# Altium schematic sheet SensorBoardSchm.SchDoc
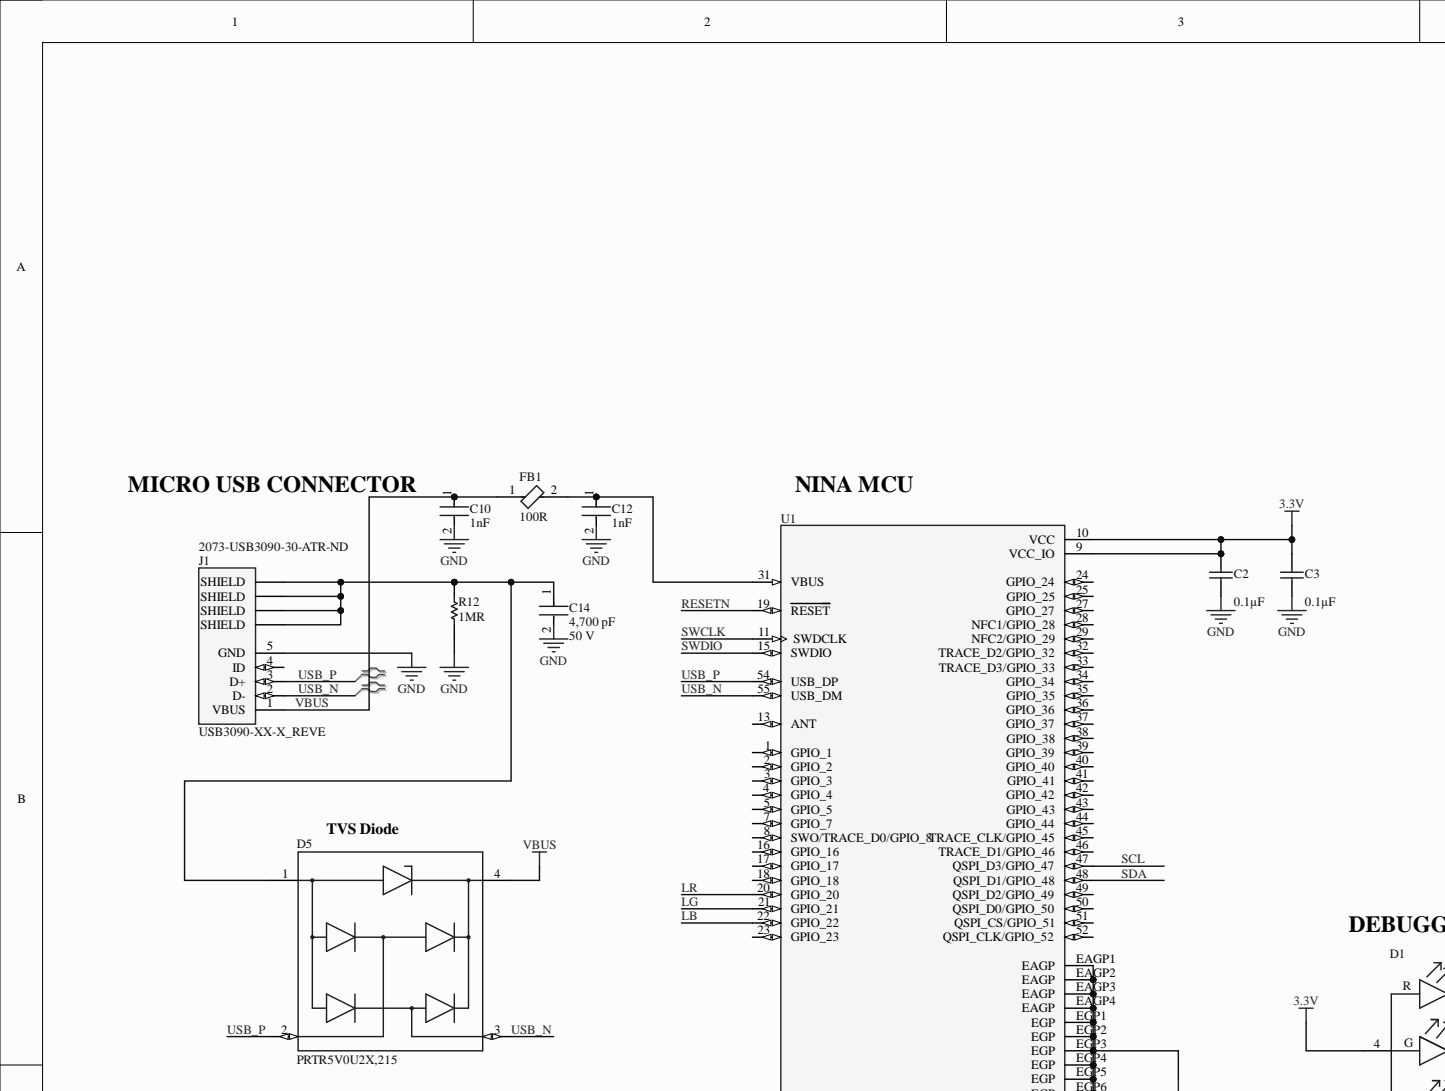
[diagram p0: SensorBoardSchm.SchDoc, top left]
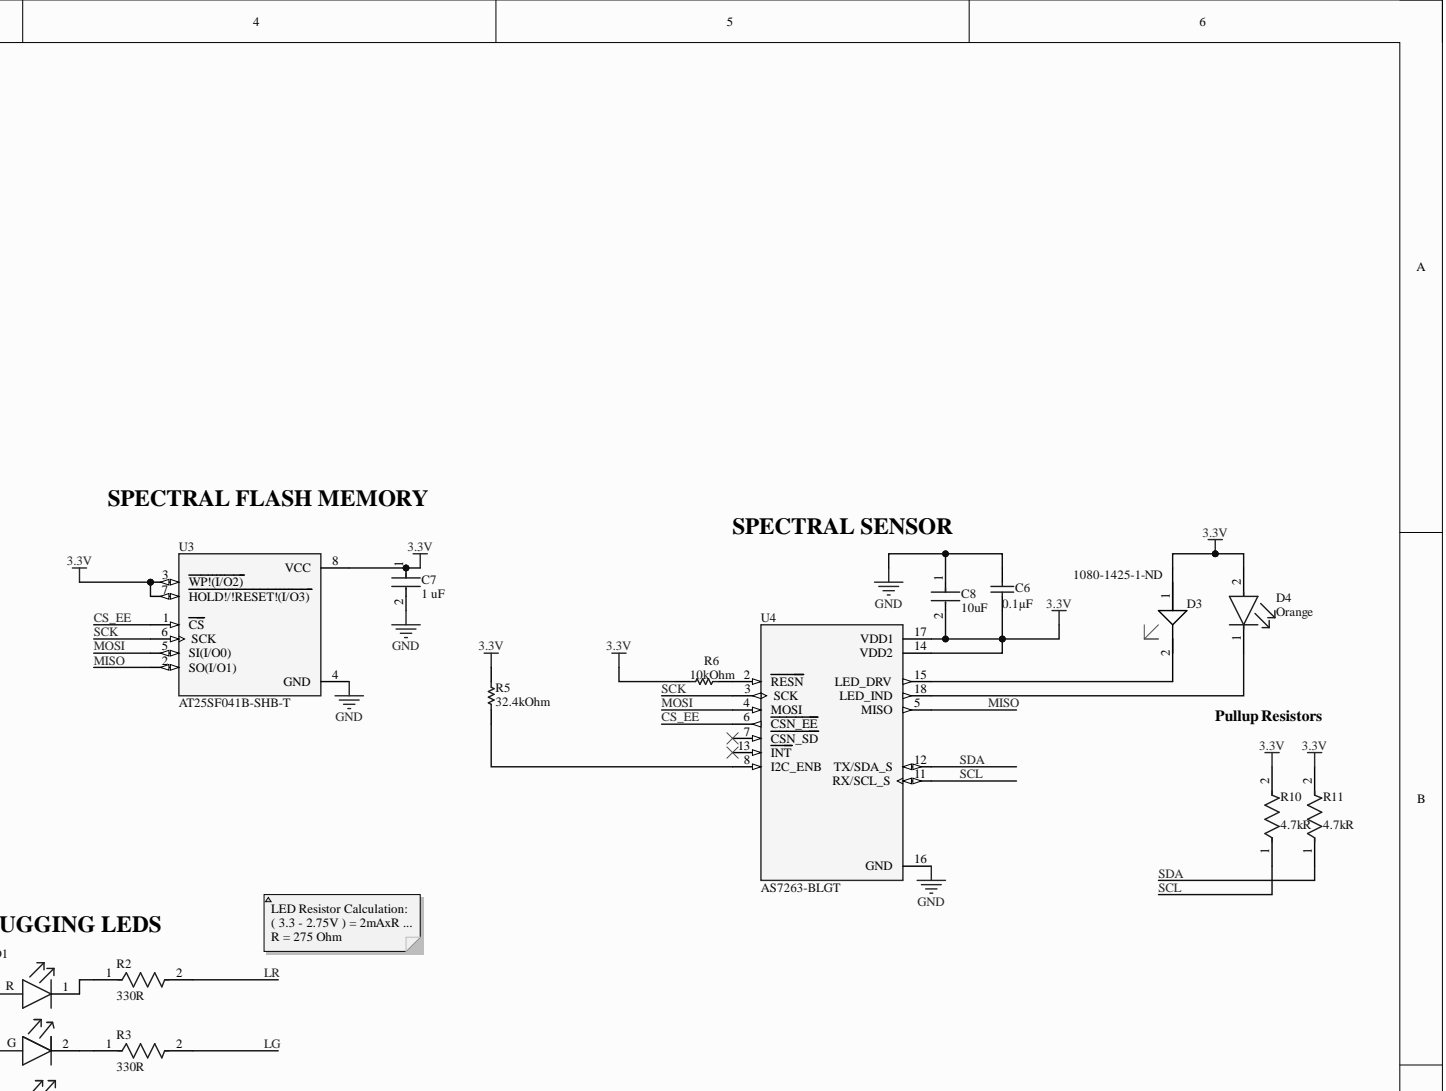
[diagram p1: SensorBoardSchm.SchDoc, top right]
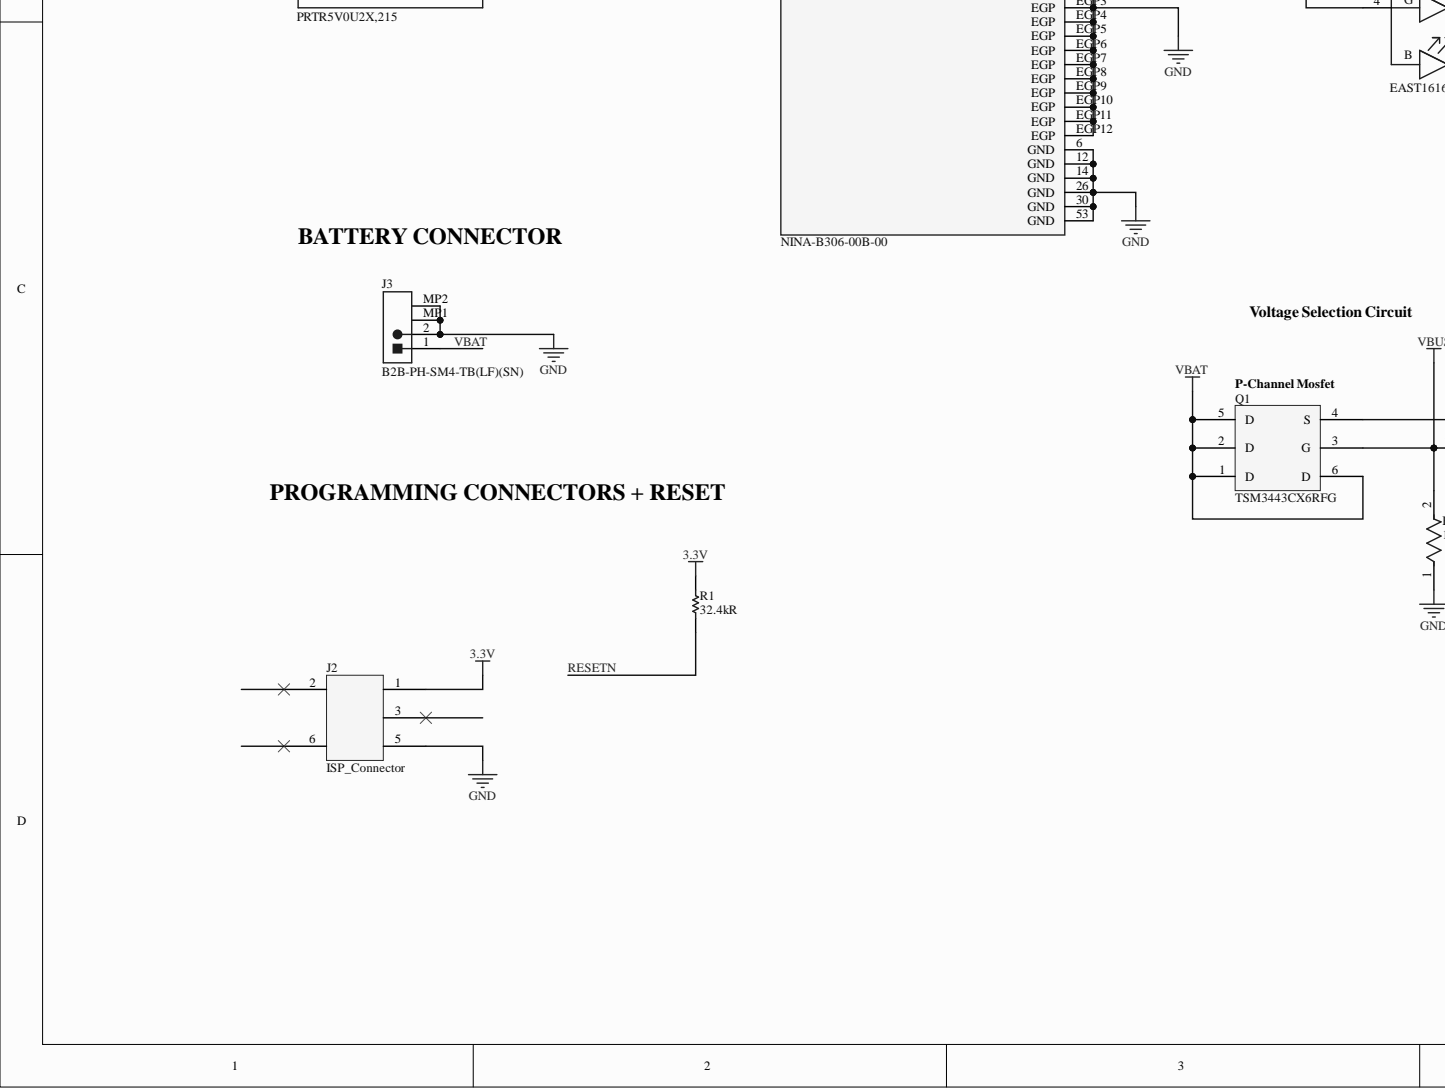
[diagram p2: SensorBoardSchm.SchDoc, bottom left]
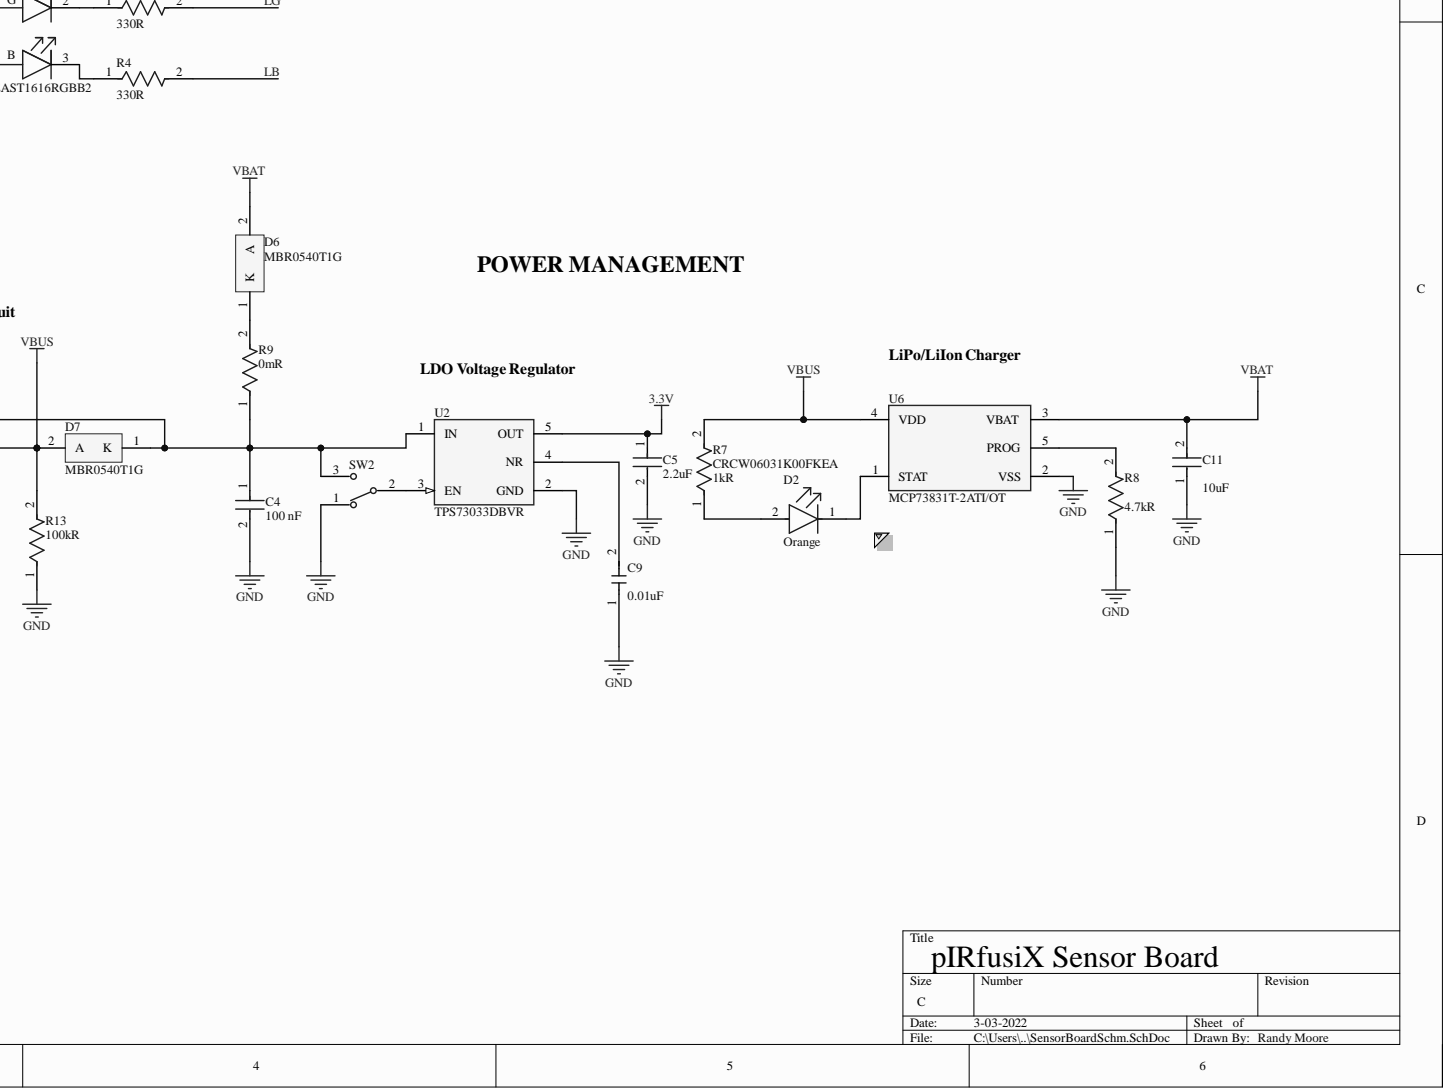
[diagram p3: SensorBoardSchm.SchDoc, bottom right]

## Parts
C2  Capacitance: 0.1µF  [SAMS-C0603-2_M]  (hidden comment: CL10B104KB8NNNC)
C3  Capacitance: 0.1µF  [SAMS-C0603-2_M]  (hidden comment: CL10B104KB8NNNC)
C4  value 100 nF  Capacitance: 100 nF  [FP-CC0603-DA-MFG]  (hidden comment: CC0603KRX7R7BB104)
C5  Capacitance: 2.2uF  [FP-GRM188-0_1-e0_2_0_5-MFG]  (hidden comment: GRM188R71A225KE15D)
C6  Capacitance: 0.1µF  [SAMS-C0603-2_M]  (hidden comment: CL10B104KB8NNNC)
C7  value 1 uF  Capacitance: 1 uF  [FP-CC0603-DA-MFG]  (hidden comment: CC0603KPX7R7BB105)
C8  Capacitance: 10uF  [FP-GRM188-0_1-e0_2_0_55-IPC_A]  (hidden comment: GRM188R60J106ME47D)
C9  Capacitance: 0.01uF  [RESC1608X55N]  (hidden comment: C0603C103K3RACTU)
C10  Capacitance: 1nF  [FP-GRM188-0_1-e0_2_0_5-IPC_C]  (hidden comment: GRM1885C1H102JA01D)
C11  Capacitance: 10uF  [FP-C1608-080-0_1-MFG]  (hidden comment: C1608X5R0J106M080AB)
C12  Capacitance: 1nF  [FP-GRM188-0_1-e0_2_0_5-IPC_C]  (hidden comment: GRM1885C1H102JA01D)
C14  value 4,700 pF  Capacitance: 4,700 pF  Voltage Rating: 50 V  [FP-C0603C-CF-MFG]  (hidden comment: C0603C472J5GACTU)
D1  EAST1616RGBB2  [FP-EAST1616RGBB2-MFG]
D2  Colour: Orange  [FP-6_541-5056_01-4-MFG]  (hidden comment: TLMO1000-GS08)
D3  Supplier Part Number 1: 1080-1425-1-ND  [SIR19-21C/TR8]  (hidden comment: SIR19-21C/TR8)
D4  Colour: Orange  [FP-6_541-5056_01-4-MFG]  (hidden comment: TLMO1000-GS08)
D5  PRTR5V0U2X,215  [FP-SOT143B-MFG]
D6  MBR0540T1G  [ONSC-SOD-123-2-425-04_V]
D7  MBR0540T1G  [ONSC-SOD-123-2-425-04_V]
FB1  Impedance: 100R  [FP-BLM18-0_15-t0_5-MFG]  (hidden comment: BLM18EG101TN1D)
J1  USB3090-XX-X_REVE  Supplier Part Number 1: 2073-USB3090-30-ATR-ND  [GCT_USB3090-XX-X_REVE]
J2  ISP_Connector  [JST Programmer]
J3  B2B-PH-SM4-TB(LF)(SN)  [JST-B2B-PH-SM4-TB-2_V]
Q1  TSM3443CX6RFG  [SOT95P280X145-6N]
R1  Resistance: 32.4kR  [RESC1608X55X30ML15T15]  (hidden comment: ERJ-3EKF3242V)
R2  Resistance: 330R  [FP-CRCW0603-e3-MFG]  (hidden comment: CRCW0603330RFKEA)
R3  Resistance: 330R  [FP-CRCW0603-e3-MFG]  (hidden comment: CRCW0603330RFKEA)
R4  Resistance: 330R  [FP-CRCW0603-e3-MFG]  (hidden comment: CRCW0603330RFKEA)
R5  Resistance: 32.4kOhm  [RESC1608X55X30ML15T15]  (hidden comment: ERJ-3EKF3242V)
R6  Resistance: 10kOhm  [RESC1609X50X30ML10T20]  (hidden comment: CRCW060310K0FKTB)
R7  CRCW06031K00FKEA  Resistance: 1kR  [FP-CRCW0603-e3-MFG]
R8  Resistance: 4.7kR  [FP-CR0603-IPC_B]  (hidden comment: CR0603-FX-4701ELF)
R9  Resistance: 0mR  [FP-CRCW0603-HPe3-MFG]  (hidden comment: CRCW06030000Z0EAHP)
R10  Resistance: 4.7kR  [FP-CR0603-IPC_B]  (hidden comment: CR0603-FX-4701ELF)
R11  Resistance: 4.7kR  [FP-CR0603-IPC_B]  (hidden comment: CR0603-FX-4701ELF)
R12  Resistance: 1MR  [RESC1608X55X25LL10T15]  (hidden comment: RC0603FR-071ML)
R13  Resistance: 100kR  [FP-RC0603-0_55-MFG]  (hidden comment: RC0603FR-07100KL)
SW2  JS102011JAQN  [FP-JS102011JAQN-MFG]  (value not drawn)
U1  NINA-B306-00B-00  [XCVR_NINA-B306-00B-00]
U2  TPS73033DBVR  [FP-DBV0005A-MFG]
U3  AT25SF041B-SHB-T  [SOIC127P790X216-8N]
U4  AS7263-BLGT  [AS7263Footprint]
U6  MCP73831T-2ATI/OT  [FP-C04-091-OT-IPC_C]

## Nets
3.3V: C2.2 C3.2 C5.1 C6.1 C7.1 C8.2 D1.4 D3.1 D4.2 J2.1 R1.2 R5.2 R6.1 R10.2 R11.2 U1.9(VCC_IO) U1.10(VCC) U2.5(OUT) U3.3(WP!(I/O2)) U3.7(HOLD!/!RESET!(I/O3)) U3.8(VCC) U4.14(VDD2) U4.17(VDD1)
GND: C2.1 C3.1 C4.2 C5.2 C6.2 C7.2 C8.1 C9.1 C10.2 C11.1 C12.2 C14.2 J1.5(GND) J2.5 J3.2 J3.MP1 J3.MP2 R8.1 R12.1 R13.1 SW2.1 U1.6(GND) U1.12(GND) U1.14(GND) U1.26(GND) U1.30(GND) U1.53(GND) U1.EAGP1(EAGP) U1.EAGP2(EAGP) U1.EAGP3(EAGP) U1.EAGP4(EAGP) U1.EGP1(EGP) U1.EGP2(EGP) U1.EGP3(EGP) U1.EGP4(EGP) U1.EGP5(EGP) U1.EGP6(EGP) U1.EGP7(EGP) U1.EGP8(EGP) U1.EGP9(EGP) U1.EGP10(EGP) U1.EGP11(EGP) U1.EGP12(EGP) U2.2(GND) U3.4(GND) U4.16(GND) U6.2(VSS)
CS_EE: U3.1(CS) U4.6(CSN_EE)
LB: R4.2 U1.22(GPIO_22)
LG: R3.2 U1.21(GPIO_21)
LR: R2.2 U1.20(GPIO_20)
MISO: U3.2(SO(I/O1)) U4.5(MISO)
MOSI: U3.5(SI(I/O0)) U4.4(MOSI)
RESETN: R1.1 U1.19(RESET)
SCK: U3.6(SCK) U4.3(SCK)
SCL: R10.1 U1.47(QSPI_D3/GPIO_47) U4.11(RX/SCL_S)
SDA: R11.1 U1.48(QSPI_D1/GPIO_48) U4.12(TX/SDA_S)
SWCLK: U1.11(SWDCLK)
SWDIO: U1.15(SWDIO)
USB_N: D5.3 J1.2(D-) U1.55(USB_DM)
USB_P: D5.2 J1.3(D+) U1.54(USB_DP)
VBAT: C11.2 D6.2 J3.1 Q1.1(D) Q1.2(D) Q1.5(D) Q1.6(D) U6.3(VBAT)
VBUS: C10.1 D5.4 D7.2 FB1.1 J1.1(VBUS) Q1.3(G) R7.2 R13.2 U6.4(VDD)
NetC4_1: C4.1 D7.1 Q1.4(S) R9.1 SW2.3 U2.1(IN)
NetC9_2: C9.2 U2.4(NR)
NetC12_1: C12.1 FB1.2 U1.31(VBUS)
NetC14_1: C14.1 D5.1 J1.6(SHIELD) J1.7(SHIELD) J1.8(SHIELD) J1.9(SHIELD) R12.2
NetD1_1: D1.1 R2.1
NetD1_2: D1.2 R3.1
NetD1_3: D1.3 R4.1
NetD2_1: D2.1 U6.1(STAT)
NetD2_2: D2.2 R7.1
NetD3_2: D3.2 U4.15(LED_DRV)
NetD4_1: D4.1 U4.18(LED_IND)
NetD6_1: D6.1 R9.2
NetR5_1: R5.1 U4.8(I2C_ENB)
NetR6_2: R6.2 U4.2(RESN)
NetR8_2: R8.2 U6.5(PROG)
NetSW2_2: SW2.2 U2.3(EN)

Not connected: J1 4(ID); J2 2 3 6; U1 1(GPIO_1) 2(GPIO_2) 3(GPIO_3) 4(GPIO_4) 5(GPIO_5) 7(GPIO_7) 8(SWO/TRACE_D0/GPIO_8) 13(ANT) 16(GPIO_16) 17(GPIO_17) 18(GPIO_18) 23(GPIO_23) 24(GPIO_24) 25(GPIO_25) 27(GPIO_27) 28(NFC1/GPIO_28) 29(NFC2/GPIO_29) 32(TRACE_D2/GPIO_32) 33(TRACE_D3/GPIO_33) 34(GPIO_34) 35(GPIO_35) 36(GPIO_36) 37(GPIO_37) 38(GPIO_38) 39(GPIO_39) 40(GPIO_40) 41(GPIO_41) 42(GPIO_42) 43(GPIO_43) 44(GPIO_44) 45(TRACE_CLK/GPIO_45) 46(TRACE_D1/GPIO_46) 49(QSPI_D2/GPIO_49) 50(QSPI_D0/GPIO_50) 51(QSPI_CS/GPIO_51) 52(QSPI_CLK/GPIO_52); U4 7(CSN_SD) 13(INT)

## Library data of the parts
C2 C3 C6 = CMP-2000-05332-1: Multi-layer Ceramic Capacitor, -55 to 125 degC, 2-Pin SMD (0603), RoHS, Tape and Reel; Manufacturer: Samsung Electro-Mechanics; Dielectric: X7R; Rated Voltage: 50V; Tolerance (%): ±10%; Case Size/Dimension: 0603; Component Kind: Standard; Datasheet URL: https://datasheet.ciiva.com/29198/456651-da-01-en-vs-kondensator-0605-29198839.pdf; Datasheet Version: Nov-10; Library Name: Altium Content Vault; Manufacturer URL: http://www.samsungsem.com/global/index.jsp
C4 = CMP-2000-05002-2: CAP CER 0.1UF 16V X7R 0603; Manufacturer: YAGEO; Material: Ceramic; Temperature Coefficient: 15%; Tolerance: 0.1; Automotive: No; Category: Cap; Component Kind: Standard; ComponentLink2Description: Datasheet; ComponentLink2URL: http://www.yageo.com/documents/recent/UPY-GPHC_X7R_6.3V-to-50V_18.pdf; Device Class L1: Passive Components; Device Class L2: Capacitors; Device Class L3: Ceramic Capacitors; Digikey Description: CAP CER 0.1UF 16V X7R 0603; Footprint Url: http://www.yageo.com/exep/pages/download/literatures/UPY-C_GEN_24.pdf; Height: 0.9mm; Lead Free: Yes; Library Name: Altium Content Vault; Manufacturer Part Number: CC0603KRX7R7BB104; Mouser Description: Multilayer Ceramic Capacitor, CC Series, 0.1 - F, - 10%, X7R, 16 V, 0603 [1608 Metric]; Octopart: https://octopart.com/cc0603krx7r7bb104-yageo-192941; Package: CC0603-DA; RoHS: TRUE; Temperature: -55°C to +125°C; Temperature Characteristic: X7R; Temperature Range High: +125°C; Temperature Range Low: -55°C; Voltage: 16 V; Voltage Rating: 16 V
C5 = CMP-2006-02836-2: Chip Multilayer Ceramic Capacitors for General Purpose, 0603, 2.2uF, X7R, 15%, 10%, 10V; Case Code (Imperial): 0603; Case Code (Metric): 1608; Case/Package: 0603; Component Kind: Standard; Depth: 0.8mm; Dielectric: X7R; Height: 0.8mm; Lead Free: Lead Free; Length: 1.6mm; Library Name: Altium Content Vault; Material: Ceramic; Max Operating Temperature: 125°C; Min Operating Temperature: -55°C; Mount: Surface Mount; Number of Pins: 2; Package Quantity: 1; Packaging: Cut Tape; REACH SVHC: No SVHC; Termination: SMD/SMT; Thickness: 0.035inch; Tolerance: 10%; Voltage: 10V; Voltage Rating: 10V; Voltage Rating (DC): 10V; Weight: 7.1E-05oz; Width: 0.031inch
C7 = CMP-03422-001047-1: CC0603 1 uF X7R 10.00% 16 V; Manufacturer: YAGEO; Material: Ceramic; Temperature Coefficient: 15%; Tolerance: 0.1; Voltage: 16 V; Automotive: No; Category: Cap; Component Kind: Standard; ComponentLink2Description: Datasheet; ComponentLink2URL: http://www.yageo.com/documents/recent/UPY-GPHC_X7R_6.3V-to-50V_18.pdf; Device Class L1: Passive Components; Device Class L2: Capacitors; Device Class L3: Ceramic Capacitors; Footprint Url: http://www.yageo.com/exep/pages/download/literatures/UPY-C_GEN_24.pdf; Height: 0.9mm; Lead Free: Yes; Library Name: Altium Content Vault; Manufacturer Part Number: CC0603KPX7R7BB105; Mouser Description: Cap Ceramic 1uF 16V X7R 10% SMD 0603 125°C Paper T/R; Octopart: https://octopart.com/cc0603kpx7r7bb105-yageo-25629533; Package: CC0603-DA; RoHS: TRUE; Temperature: -55°C to +125°C; Temperature Characteristic: X7R; Temperature Range High: +125°C; Temperature Range Low: -55°C; Voltage Rating: 16 V
C8 = CMP-2006-02792-2: Chip Multilayer Ceramic Capacitors for General Purpose, 0603, 10uF, X5R, 15%, 20%, 6.3V; Case Code (Imperial): 0603; Case Code (Metric): 1608; Case/Package: 0603; Component Kind: Standard; Depth: 0.8mm; Dielectric: X5R; Height: 0.8mm; Lead Free: Lead Free; Length: 1.6mm; Library Name: Altium Content Vault; Material: Ceramic; Max Operating Temperature: 85°C; Min Operating Temperature: -55°C; Mount: Surface Mount; Package Quantity: 4000; Packaging: Tape and Reel; Radiation Hardening: No; REACH SVHC: No SVHC; Termination: SMD/SMT; Thickness: 0.035inch; Tolerance: 20%; Voltage: 6.3V; Voltage Rating: 6.3V; Voltage Rating (DC): 6.3V; Width: 0.031inch
C10 C12 = CMP-1662-00022-2: Chip Multilayer Ceramic Capacitors for General Purpose, 0603, 1000pF, C0G, 30ppm/°C, 5%, 50V; Insulation Resistance: 10GR; Case Code (Imperial): 0603; Case Code (Metric): 1608; Case/Package: 0603; Component Kind: Standard; Depth: 0.8mm; Dielectric: C0G; Dielectric Material: Ceramic; Height: 0.8mm; Lead Free: Lead Free; Length: 1.6mm; Library Name: Altium Content Vault; Material: Ceramic; Max Operating Temperature: 125°C; Min Operating Temperature: -55°C; Mount: Surface Mount; Number of Pins: 2; Package Quantity: 1; Packaging: Tape and Reel; Radiation Hardening: No; REACH SVHC: No SVHC; Temperature Coefficient: 30ppm/°C; Termination: SMD/SMT; Thickness: 0.035inch; Tolerance: 5%; Voltage: 50V; Voltage Rating: 50V; Voltage Rating (DC): 50V; Width: 0.8mm
C11 = CMP-2000-04978-2: Multilayer Ceramic Capacitors 10μF ±20% 6.3V X5R SMD 0603; Case Code (Imperial): 0603; Case Code (Metric): 1608; Case/Package: 0603; Component Kind: Standard; Construction: Flat; Depth: 0.8mm; Dielectric: X5R; Dielectric Material: Ceramic; Height: 0.8mm; Lead Free: Lead Free; Length: 1.6mm; Library Name: Altium Content Vault; Max Operating Temperature: 85°C; Min Operating Temperature: -55°C; Mount: Surface Mount; Package Quantity: 1; Packaging: Tape and Reel; Radiation Hardening: No; REACH SVHC: No SVHC; Resistor Type: Ceramic; RoHS Compliant: Yes; Series: C; Termination: SMD/SMT; Thickness: 0.035inch; Tolerance: 20%; Voltage: 6.3V; Voltage Rating: 6.3V; Voltage Rating (DC): 6.3V; Weight: 7.1E-05oz; Width: 0.031inch
C14 = CMP-2006-03333-2: CAP CER 4700PF 50V 5% NP0 0603; Manufacturer: KEMET; Automotive Grade: Grade 1; Material: Ceramic; Temperature Characteristic: C0G; Temperature Coefficient: 30ppm/°C; Tolerance: 0.05; Automotive: Yes; Category: Cap; Component Kind: Standard; ComponentLink2Description: Datasheet; ComponentLink2URL: https://api.kemet.com/component-edge/download/datasheet/C0603C100J5GACAUTO.pdf; Device Class L1: Passive Components; Device Class L2: Capacitors; Device Class L3: Ceramic Capacitors; Digikey Description: CAP CER 4700PF 50V 5% NP0 0603; Height: 0.87mm; Lead Free: Yes; Library Name: Altium Content Vault; Manufacturer Part Number: C0603C472J5GACTU; Mouser Description: Multilayer Ceramic Capacitors MLCC - SMD/SMT 50volts 4700pF 5% C0G; Octopart: https://octopart.com/c0603c472j5gactu-kemet-9145067; Package: C0603C-CF; RoHS: TRUE; Temperature: -55°C to +125°C; Temperature Range High: +125°C; Temperature Range Low: -55°C
D1 = CMP-51564-000002-1: LED RGB DIFFUSED 4SMD; Manufacturer: Everlight; Colour: Blue, Green, Red; Forward Current: 5mA; Forward Voltage: 3/3/2.1V; Led Orientation: Top View; Luminous Intensity: 36/140/45mcd; Peak Emmision Wavelength: 468/518/632nm; Power Dissipation: 95/95/60mW; Reverse Voltage: 5V; Viewing Angle: 120°; Wavelength: 475/535/628nm; Automotive: No; Category: Diode; Component Kind: Standard; ComponentLink2Description: Datasheet; ComponentLink2URL: https://everlightamericas.com/index.php?controller=attachment&id_attachment=2426; Device Class L1: Optoelectronics; Device Class L2: LEDs; Device Class L3: unset; Digikey Description: LED RGB DIFFUSED 4SMD; Height: 0.45mm; Lead Free: Yes; Library Name: Altium Content Vault; Manufacturer Part Number: EAST1616RGBB2; Octopart: https://octopart.com/east1616rgbb2-everlight-80328051; Package: 0; RoHS: TRUE; Temperature: -40°C to +85°C; Temperature Range High: +85°C; Temperature Range Low: -40°C
D2 D4 = CMP-02520-000010-1: LED ORANGE 0603 SMD; Manufacturer: Vishay; Automotive Grade: Grade 2; Forward Current: 2mA; Forward Voltage: 1.8V; Led Orientation: Top View; Luminous Intensity: 7.5mcd; Max Junction Temp: +120°C; Peak Emmision Wavelength: 610nm; Power Dissipation: 40mW; Reverse Voltage: 6V; Viewing Angle: 160°; Wavelength: 605nm; Ambient Temperature Range High: +100°C; Ambient Temperature Range Low: -40°C; Automotive: Yes; Category: Diode; Component Kind: Standard; ComponentLink2Description: Datasheet; ComponentLink2URL: http://www.vishay.com/docs/83172/tlmo1000.pdf; Device Class L1: Optoelectronics; Device Class L2: LEDs; Device Class L3: unset; Digikey Description: LED ORANGE 0603 SMD; Height: 0.65mm; Lead Free: Yes; Library Name: Altium Content Vault; Manufacturer Part Number: TLMO1000-GS08; Octopart: https://octopart.com/tlmo1000-gs08-vishay-41955669; Package: 6.541-5056.01-4; RoHS: TRUE; Temperature: -40°C to +100°C; Temperature Range High: +100°C; Temperature Range Low: -40°C
D5 = CMP-14436-000007-1: TVS DIODE 5.5V SOT143B; Component Kind: Standard; Library Name: Altium Content Vault
D6 D7 = CMP-1055-00401-1: Schottky Power Rectifier, 2-Pin SOD-123, Pb-Free, Tape and Reel; Manufacturer: On Semiconductor; Configuration: Single; IFSM Max (A): 5.5; Component Kind: Standard; ComponentLink1Description: Manufacturer URL; ComponentLink1URL: http://www.onsemi.com/; ComponentLink2Description: Datasheet; ComponentLink2URL: http://www.onsemi.cn/pub_link/Collateral/MBR0540T1-D.PDF; ComponentLink3Description: Package Specification; ComponentLink3URL: http://www.onsemi.com/pub_link/Collateral/425-04.PDF; DatasheetVersion: Rev. 7; Library Name: Altium Content Vault; Mounting Technology: Surface Mount; PackageDescription: 2-Pin SOD, Body 1.6 x 2.69 mm; PackageReference: SOD-123-2-425-04; PackageVersion: Rev. G, 10/2009; Packing: Tape and Reel; PartNumber: MBR0540T1G; RoHS: TRUE
FB1 = CMP-0686-00530-3: Chip Ferrite Bead, 0603, 100Ω @ 100MHz, 25%, 0.045Ω, 2A; DC Resistance (DCR): 45mR; Number of Circuits: 1; Case Code (Imperial): 0603; Case Code (Metric): 1608; Case/Package: 0603; Component Kind: Standard; Contact Plating: Tin; Core Material: Ferrite; Current Rating: 2A; Depth: 0.8mm; Height: 0.8mm; Lead Free: Lead Free; Length: 1.6mm; Library Name: Altium Content Vault; Material: Ferrite; Max Current Rating: 2A; Max DC Current: 2A; Max Operating Temperature: 125°C; Min Operating Temperature: -55°C; Number of Lines: 1; Number of Pins: 2; Package Quantity: 1; Packaging: Tape and Reel; REACH SVHC: No SVHC; Termination: SMD/SMT; Test Frequency: 100MHz; Tolerance: 25%; Width: 0.8mm
J1 = USB3090-XX-X_REVE: USB - micro AB USB 2.0 Receptacle Connector 5 Position Surface Mount, Right Angle; Through Hole; MF: Global Connector Technology; MP: USB3090-30-A; Availability: Unavailable; Component Kind: Standard; Library Name: USB3090-XX-X_REVE.IntLib; Package: None; Price: None; SnapEDA_Link: https://www.snapeda.com/parts/USB3090-30-A/Global%20Connector%20Technology/view-part/281875/?ref=snap; Supplier 1: Digi-Key
J3 = CMP-2000-05163-1: Male Header, Pitch 2 mm, 1 x 2 Position, Height 6.6 mm, RoHS, Tape and Reel; Manufacturer: JST; No of Positions: 2; Pitch: 2mm; Style/Orientation: StraightHeader/Plug; Component Kind: Standard; Datasheet URL: https://ciiva.com/datasheet-click-26894756?src=ciiva&sig=90099765; Datasheet Version: Dec-12; Library Name: Altium Content Vault; Manufacturer URL: http://www.jst.com/home2.html; Termination: Crimp; Type: ShroudedHeader
R1 R5 = CMP-2000-00433-1: Manufacturer: Panasonic; Component Kind: Standard; Library Name: Altium Content Vault; Part Number: ERJ-3EKF3242V
R2-R4 = CMP-1012-00454-3: RES Thick Film, 330Ω, 1%, 0.1W, 100ppm/°C, 0603; Max Power Dissipation: 100mW; Voltage Rating: 75V; Case Code (Imperial): 0603; Case Code (Metric): 1608; Case/Package: 0603; Component Kind: Standard; Composition: Thick Film; Contact Plating: Tin; Depth: 0.85mm; Features: Automotive AEC-Q200; Height: 0.45mm; Lead Free: Lead Free; Length: 1.55mm; Library Name: Altium Content Vault; Max Operating Temperature: 155°C; Military Standard: Not; Min Operating Temperature: -55°C; Mount: Surface Mount; Number of Terminations: 2; Package Quantity: 10; Packaging: Tape and Reel; Power Rating: 100mW; Radiation Hardening: No; Temperature Coefficient: 100ppm/°C; Termination: SMD/SMT; Tolerance: 1%; Voltage Rating (DC): 75V; Weight: 7.1E-05oz; Width: 0.033inch
R6 = CMP-2000-03837-1: Manufacturer: Vishay Dale; Component Kind: Standard; Library Name: Altium Content Vault; Part Number: CRCW060310K0FKTB
R7 = CMP-1666-00003-5: RES Thick Film, 1kΩ, 1%, 0.1W, 100ppm/°C, 0603; Max Power Dissipation: 100mW; Voltage Rating: 75V; Case Code (Imperial): 0603; Case Code (Metric): 1608; Case/Package: 0603; Component Kind: Standard; Composition: Thick Film; Contact Plating: Tin; Depth: 0.85mm; Features: Automotive AEC-Q200; Height: 0.45mm; Lead Free: Lead Free; Length: 1.55mm; Library Name: Altium Content Vault; Max Operating Temperature: 155°C; Military Standard: Not; Min Operating Temperature: -55°C; Mount: Surface Mount; Number of Pins: 2; Number of Terminations: 2; Package Quantity: 10; Packaging: Tape and Reel; Power Rating: 100mW; Radiation Hardening: No; Temperature Coefficient: 100ppm/°C; Termination: SMD/SMT; Tolerance: 1%; Voltage Rating (DC): 75V; Weight: 7.1E-05oz; Width: 0.033inch
R8 R10 R11 = CMP-07231-003020-1: Thick Film Chip Resistors 0603 4.7kΩ 0.1W 1% 100ppm/°C; Max Power Dissipation: 100mW; Voltage Rating: 50V; Case Code (Imperial): 0603; Case Code (Metric): 1608; Case/Package: 0603; Component Kind: Standard; Composition: Thick Film; Contact Plating: Tin; Depth: 0.8mm; Features: Moisture Resistant; Height: 0.45mm; Length: 1.6mm; Library Name: Altium Content Vault; Max Operating Temperature: 155°C; Min Operating Temperature: -55°C; Mount: Surface Mount; Number of Pins: 2; Number of Terminations: 2; Package Quantity: 1; Packaging: Tape and Reel; Power Rating: 100mW; Radiation Hardening: No; REACH SVHC: No SVHC; Temperature Coefficient: 100ppm/°C; Termination: SMD/SMT; Thickness: 457um; Tolerance: 1%; Voltage Rating (DC): 50V; Width: 0.8mm
R9 = CMP-2000-03817-2: RES Thick Film, 0Ω, , 0.33W, , 0603; Max Power Dissipation: 250mW; Temperature Coefficient: 0ppm/°C; Voltage Rating: 75V; Case Code (Imperial): 0603; Case Code (Metric): 1608; Case/Package: 0603; Component Kind: Standard; Composition: Thick Film; Contact Plating: Tin; Depth: 0.85mm; Features: Automotive AEC-Q200; Height: 0.45mm; Lead Free: Lead Free; Length: 1.6mm; Library Name: Altium Content Vault; Max Operating Temperature: 155°C; Military Standard: Not; Min Operating Temperature: -55°C; Mount: Surface Mount; Number of Pins: 2; Number of Terminations: 2; Package Quantity: 5000; Packaging: Tape and Reel; Power Rating: 250mW; Radiation Hardening: No; Termination: SMD/SMT; Voltage Rating (DC): 75V; Weight: 7.1E-05oz; Width: 0.033inch
R12 = CMP-1659-00056-1: Chip Resistor, 1 MOhm, +/- 1%, 100 mW, -55 to 155 degC, 0603 (1608 Metric), RoHS, Tape and Reel; Capacitance: 1 mF; Case\Package: Radial; Tolerance: 20%; Voltage Rating: 25 V; Component Kind: Standard; ComponentLink1Description: Manufacturer URL; ComponentLink1URL: http://www.yageo.com/; ComponentLink2Description: Datasheet; ComponentLink2URL: http://www.yageo.com/documents/recent/PYu-RC_Group_51_RoHS_L_04.pdf; DatasheetVersion: Rev. 4, 02/2016; Diameter: 10 mm; Height: 20 mm; Lead Pitch: 5 mm; Length: 20 mm; Library Name: Altium Content Vault; Max Operating Temperature: 85 degC; Min Operating Temperature: -40 degC; Mount: Through Hole; Package Quantity: 200; PackageDescription: 2-Pin Surface Mount Device, Body 1.6 x 0.8 mm; PackageReference: 0603; Packaging: Bulk; Radiation Hardening: No; REACH SVHC: No SVHC; RoHSCompliant: true; Voltage Rating (DC): 25 V; Width: 0 inch
R13 = CMP-2100-03662-2: RES SMD 100K OHM 1% 1/10W 0603; Max Power Dissipation: 100mW; Temperature Coefficient: 100ppm/°C; Voltage Rating: 50V; Working Voltage: 75V; Case Code (Imperial): 0603; Case Code (Metric): 1608; Case/Package: 0603; Component Kind: Standard; Construction: Rectangular; Depth: 0.8mm; Features: Moisture Resistant; Height: 0.45mm; Lead Free: Lead Free; Length: 1.6mm; Library Name: Altium Content Vault; Max Operating Temperature: 155°C; Military Standard: Not; Min Operating Temperature: -55°C; Number of Pins: 2; Number of Terminations: 2; Packaging: Tape and Reel; Power Rating: 100mW; REACH SVHC: No SVHC; Resistance Tolerance: 1%; Resistor Type: Thick Film; RoHS Compliant: Yes; Series: RC; Technology: THICK FILM; Termination: SMD/SMT; Tolerance: 1%; Voltage Rating (DC): 50V; Width: 0.8mm
SW2 = CMP-10038-000001-1: SWITCH SLIDE SPDT 300MA 6V; Manufacturer: C&K; Contact Resistance: 70mΩ; Electromechanical Life: 5000Cycles; Automotive: No; Category: Switch; Component Kind: Standard; ComponentLink2Description: Datasheet; ComponentLink2URL: https://www.ckswitches.com/media/1422/js.pdf; Contact Current Rating: 300mA; Device Class L1: Electromechanical; Device Class L2: Switches; Device Class L3: Slide Switches; Digikey Description: SWITCH SLIDE SPDT 300MA 6V; Height: 3.5mm; Lead Free: Yes; Library Name: Altium Content Vault; Manufacturer Part Number: JS102011JAQN; Mount: Surface Mount; Mouser Description: JS Series Sub-Miniature Slide Switch SPDT Right-Angle SMT; Octopart: https://octopart.com/js102011jaqn-c%26k+components-74831566; Package: JS102011JAQN; RoHS: TRUE; Temperature: -40°C to +85°C; Temperature Range High: +85°C; Temperature Range Low: -40°C; Throw Configuration: SPDT; Voltage Rating Dc: 6V
U1 = NINA-B306-00B-00: With internal PCB antenna, 10.0 x 15.0 x 2.2 mm, use with open CPU; MF: u-blox; Availability: Unavailable; Component Kind: Standard; Library Name: SensorBoardSchLib.SchLib; MP: NINA-B306-00B-00; Package: SMD-55 u-blox; Price: None; SnapEDA_Link: https://www.snapeda.com/parts/NINA-B306-00B-00/u-blox/view-part/4176731/?ref=snap
U2 = CMP-04917-000026-1: IC REG LINEAR 3.3V 200MA SOT23-5; Manufacturer: Texas Instruments; Accuracy Percentage: 2%; Dropout Voltage: 120mV; Max Junction Temp: +125°C; Max Supply Voltage: 5.5V; Min Supply Voltage: 2.7V; Nominal Supply Current: 170uA; Number Of Outputs: 1; Output Type: Fixed; Standoff Height: 0mm; Automotive: No; Category: IC; Component Kind: Standard; ComponentLink2Description: Datasheet; ComponentLink2URL: http://www.ti.com/lit/ds/symlink/tps730.pdf; Device Class L1: Integrated Circuits (ICs); Device Class L2: Power Management ICs; Device Class L3: Voltage Regulators - Linear; Digikey Description: IC REG LINEAR 3.3V 200MA SOT23-5; Height: 1.45mm; Lead Free: Yes; Library Name: Altium Content Vault; Manufacturer Part Number: TPS73033DBVR; Mouser Description: IC REG LINEAR 3.3V 200MA SOT23-5; Octopart: https://octopart.com/tps73033dbvr-texas+instruments-525554; Output Current: 200mA; Output Voltage: 3.3V; Package: DBV0005A; RoHS: TRUE; Temperature: -40°C to +125°C; Temperature Range High: +125°C; Temperature Range Low: -40°C
U3 = AT25SF041B-SHB-T: 4 Mbit, 3.0V (2.7V to 3.6V), -40C to 85C, SOIC-W 208mil (Tape & Reel), Single, Dual, Quad SPI NOR flash; MF: Dialog Semiconductor; Availability: Warning; Check_prices: https://www.snapeda.com/parts/AT25SF041B-SHB-T/Dialog%20Semiconductor/view-part/5488498/?ref=eda; Component Kind: Standard; Library Name: SensorBoardSchLib.SchLib; MP: AT25SF041B-SHB-T; Package: SOIC-8 Dialog Semiconductor; Price: None; Purchase-URL: https://pricing.snapeda.com/search/part/AT25SF041B-SHB-T/?ref=eda; SnapEDA_Link: https://www.snapeda.com/parts/AT25SF041B-SHB-T/Dialog%20Semiconductor/view-part/5488498/?ref=snap; Supplier 1: Digi-Key; Supplier Part Number 1: 1265-AT25SF041B-SHB-TCT-ND
U4 = AS7263-BLGT: Optical Sensor Ambient 610nm, 680nm, 730nm, 760nm, 810nm, 860nm IÂ²C, SPI 20-BFLGA; MF: ams; Availability: Unavailable; Component Kind: Standard; Library Name: AS7263-BLGT (1).IntLib; MP: AS7263-BLGT; Package: LGA-20 ams; Price: None; SnapEDA_Link: https://www.snapeda.com/parts/AS7263-BLGT/ams/view-part/1600359/?ref=snap
U6 = CMP-0133-00144-2: IC CONTROLLR LI-ION 4.2V SOT23-5; Max Charge Current: 500mA; Max Output Voltage: 4.232V; Max Supply Voltage: 6V; Min Output Voltage: 4.168V; Min Supply Voltage: 3.75V; Number of Cells: 1; Case/Package: SOT-23; Component Kind: Standard; Height: 1.3mm; Lead Free: Lead Free; Length: 3.1mm; Library Name: Altium Content Vault; Max Operating Temperature: 85°C; Max Output Current: 500mA; Min Operating Temperature: -40°C; Mount: Surface Mount; Number of Pins: 5; Output Current: 500mA; Output Voltage: 4.2V; Package Quantity: 3000; Packaging: Tape and Reel; REACH SVHC: No SVHC; Width: 1.8mm
C9 = C0603C103K3RACTU: Component Kind: Standard; Library Name: SensorBoardSchLib.SchLib; Supplier 1: Digi-Key; Supplier Part Number 1: 399-C0603C103K3RAC7867CT-ND
D3 = SIR19-21C/TR8: Component Kind: Standard; Library Name: SensorBoardSchLib.SchLib; Supplier 1: Digi-Key
J2 = ISP_Connector: Component Kind: Standard; Library Name: SensorBoardSchLib.SchLib
Q1 = TSM3443CX6RFG: Component Kind: Standard; Library Name: SensorBoardSchLib.SchLib; Supplier 1: Digi-Key; Supplier Part Number 1: TSM3443CX6RFGCT-ND
